# Altium schematic sheet Flash Memory.SchDoc
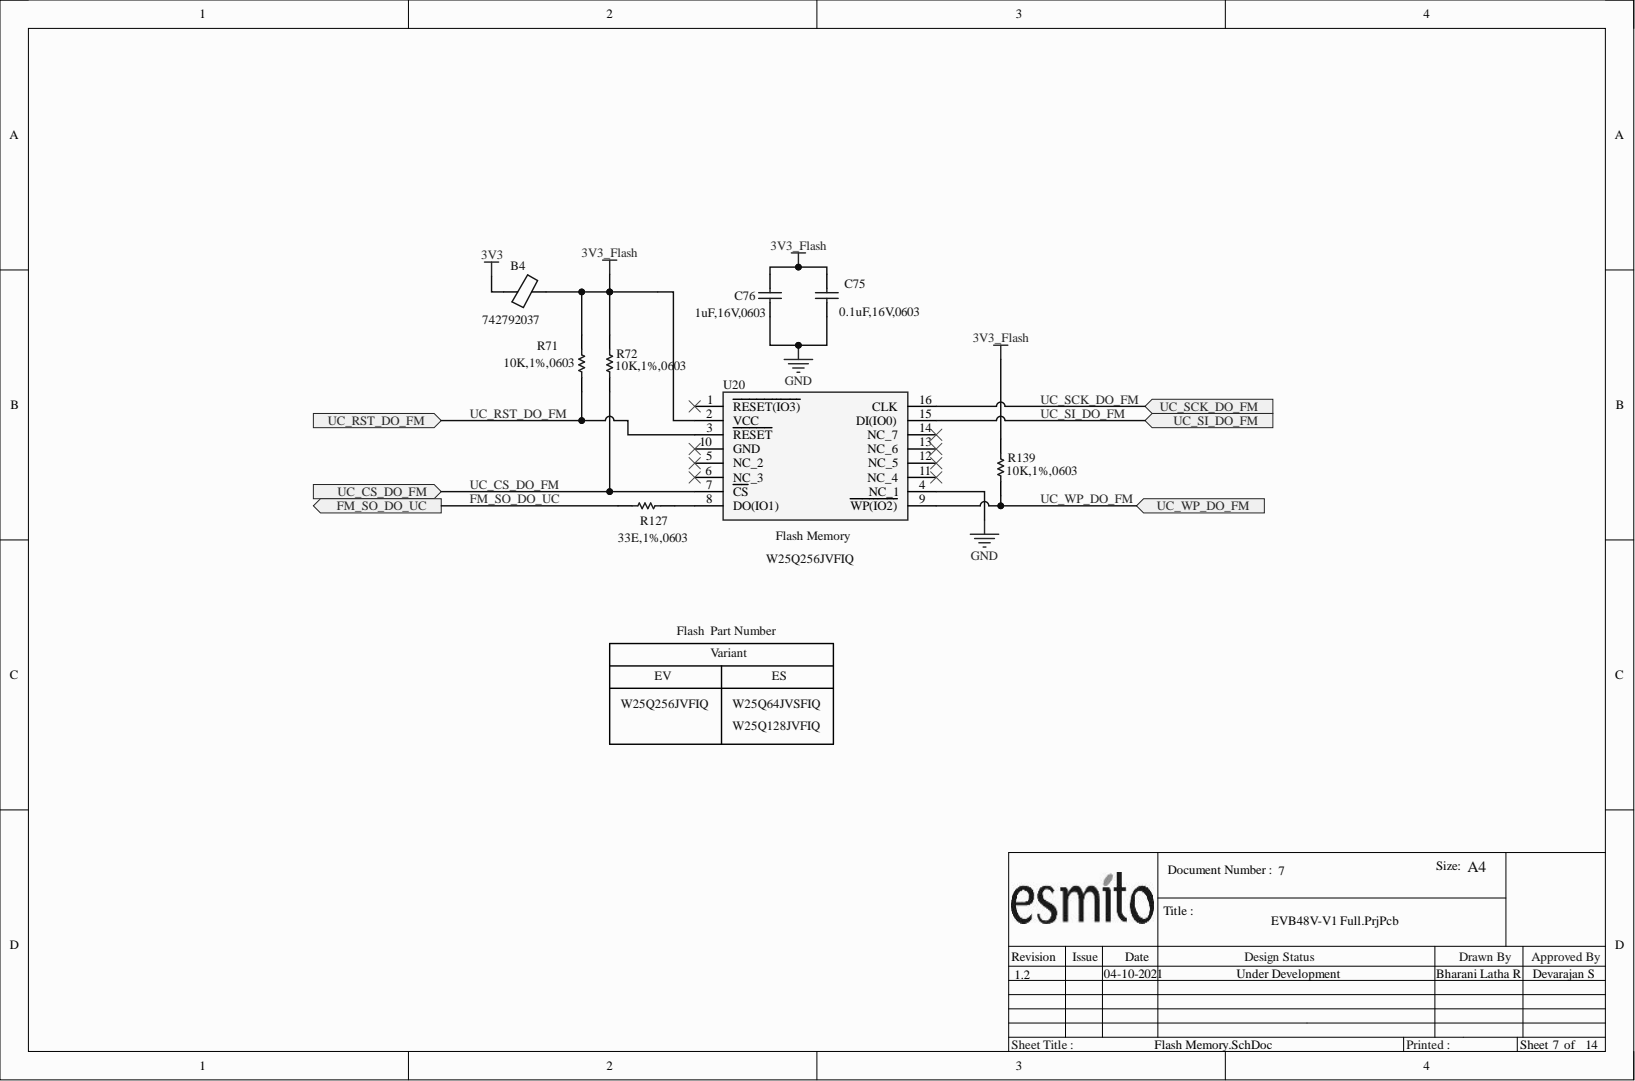
[diagram p0: Flash Memory.SchDoc]

## Parts
B4  value 742792037  Part Number: 742792037  [0805_R_M]  (hidden comment: B_LowQ)
C75  value 0.1uF,16V,0603  [0603_C_M]  (hidden comment: C_Semi)
C76  value 1uF,16V,0603  [0603_C_M]  (hidden comment: C_Semi)
R71  value 10K,1%,0603  [0603_R_M]  (hidden comment: R_Semi)
R72  value 10K,1%,0603  [0603_R_M]  (hidden comment: R_Semi)
R127  value 33E,1%,0603  [0603_R_M]  (hidden comment: R_Semi)
R139  value 10K,1%,0603  [0603_R_M]  (hidden comment: R_Semi)
U20  Flash Memory  value W25Q256JVFIQ  [SOIC127P1030X265-16M]

## Ports
FM_SO_DO_UC, UC_CS_DO_FM, UC_RST_DO_FM, UC_SCK_DO_FM, UC_SI_DO_FM, UC_WP_DO_FM

## Nets
3V3: B4.1
3V3_Flash: B4.2 C75.1 C76.1 R71.2 R72.2 R139.2 U20.2(VCC)
GND: C75.2 C76.2 U20.4(NC_1)
FM_SO_DO_UC: R127.2 ; port FM_SO_DO_UC
UC_CS_DO_FM: R72.1 U20.7(CS) ; port UC_CS_DO_FM
UC_RST_DO_FM: R71.1 U20.3(RESET) ; port UC_RST_DO_FM
UC_SCK_DO_FM: U20.16(CLK) ; port UC_SCK_DO_FM
UC_SI_DO_FM: U20.15(DI(IO0)) ; port UC_SI_DO_FM
UC_WP_DO_FM: R139.1 U20.9(WP(IO2)) ; port UC_WP_DO_FM
NetR127_1: R127.1 U20.8(DO(IO1))

Not connected: U20 1(RESET(IO3)) 5(NC_2) 6(NC_3) 10(GND) 11(NC_4) 12(NC_5) 13(NC_6) 14(NC_7)

## Library data of the parts
B4 = B_LowQ: Ferrite Bead WE-CBF 0805 SMD Bead 100MHz 330Ohm 2A; Alternate Manufacturer: Murata; Alternate Part Number: BLM21PG331SN1D; Manufacturer: Wurth Elektronik; Component Kind: Standard; Library Name: Grinntech_Global_Library.IntLib
C75 = C_Semi: MLCC - 0603 100nF 16V X7R 10%; Alternate Manufacturer: AVX; Alternate Part Number: 0603YC104K4T2A; Manufacturer: Yageo; Part Number: CC603KRX7R7BB104; Component Kind: Standard; Signal Integrity: Cap Semi; Simulation: CAP; Library Name: Grinntech_Global_Library.IntLib
C76 = C_Semi: MLCC - 0603 1uF 16V X5R 10%; Alternate Manufacturer: Murata; Alternate Part Number: GCM188R71C105KA64D; Manufacturer: Yageo; Part Number: CC603KRX5R7BB105; Component Kind: Standard; Signal Integrity: Cap Semi; Simulation: CAP; Library Name: Grinntech_Global_Library.IntLib
R71 R72 R139 = R_Semi: R, THK FLM, 10K, 1%, 100mW, 0603; Alternate Manufacturer: Vishay; Alternate Part Number: CRCW060310K0FKEA; Manufacturer: Yageo; Part Number: RC0603FR-0710KL; Component Kind: Standard; Signal Integrity: Res Semi; Simulation: RES; Library Name: Grinntech_Global_Library.IntLib
R127 = R_Semi: R, THK FLM, 33E, 1%, 100mW, 0603; Alternate Manufacturer: Yageo; Alternate Part Number: AC0603FR-0733RL; Part Number: RC0603FR-0733RL; Component Kind: Standard; Manufacturer: Yageo; Signal Integrity: Res Semi; Simulation: RES; Library Name: Grinntech_Global_Library.IntLib
U20 = W25Q256JVFIQ: NOR Flash spiFlash, 3V, 256M-bit, 4Kb; Manufacturer: Winbond; Component Kind: Standard; Part Number: W25Q256JVFIQ
